# Altium schematic sheet Battery Fuel Gauge.SchDoc
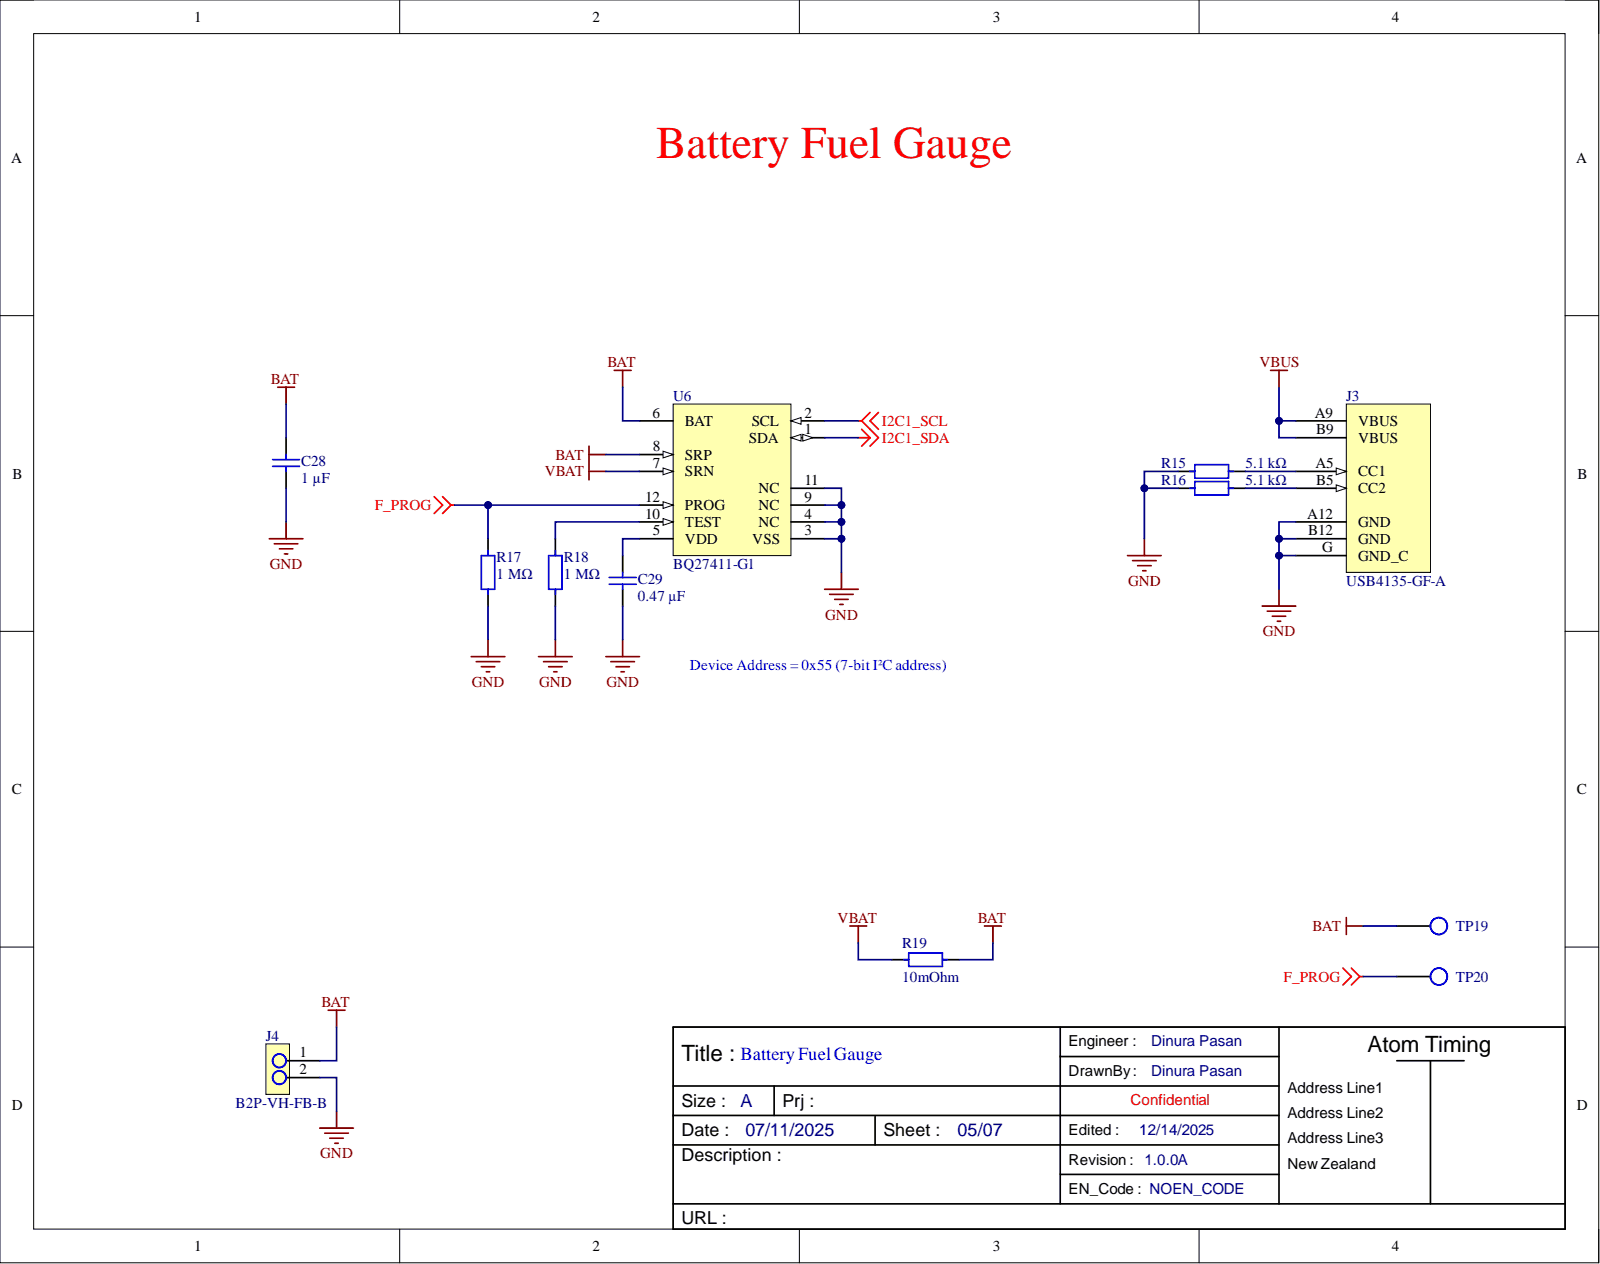
[diagram p0: Battery Fuel Gauge.SchDoc]

## Parts
C28  1 µF  [TDK_CGA0805N]
C29  0.47 µF  [TDK_CGA0805N]
J3  USB4135-GF-A  [GCT_USB4135]
J4  B2P-VH-FB-B  [B2P-VH-FB-B]
R15  5.1 kΩ  [YAGEO_RT0402N]
R16  5.1 kΩ  [YAGEO_RT0402N]
R17  1 MΩ  [YAGEO_RT0603N]
R18  1 MΩ  [YAGEO_RT0603N]
R19  10mOhm  [RL0805FR-070R01L]
TP19  TestPoint  [TESTPOINT]  (value not drawn)
TP20  TestPoint  [TESTPOINT]  (value not drawn)
U6  BQ27411-G1  [TI-DRZ0012A]

## Nets
BAT: C28.2 J4.1 R19.2 TP19.1 U6.6(BAT) U6.8(SRP)
F_PROG: R17.2 TP20.1 U6.12(PROG)
GND: C28.1 C29.1 J3.A12(GND) J3.B12(GND) J3.G(GND_C) J4.2 R15.1 R16.1 R17.1 R18.1 U6.3(VSS) U6.4(NC) U6.9(NC) U6.11(NC)
I2C1_SCL: U6.2(SCL)
I2C1_SDA: U6.1(SDA)
VBAT: R19.1 U6.7(SRN)
VBUS: J3.A9(VBUS) J3.B9(VBUS)
NetC29_2: C29.2 U6.5(VDD)
NetJ3_A5: J3.A5(CC1) R15.2
NetJ3_B5: J3.B5(CC2) R16.2
NetR18_2: R18.2 U6.10(TEST)

## Library data of the parts
C28 = CGA4J3X7R1H105K125AB: 1 µF ±10% 50V X7R -55°C ~ 125°C 0805 (2012 Metric); Manufacturer: TDK Corporation; Database Table Name: Sheet1$; Eco Plan: RoHS & Green; Initial Voltage: 0V; TEMP: 25; Value: 1u; Capacitance: 1 µF; Category: Ceramic Capacitor (MLCC); Component Kind: Standard; Datasheet: https://product.tdk.com/system/files/dam/doc/product/capacitor/ceramic/mlcc/catalog/mlcc_automotive_general_en.pdf; Library Name: MLCC.DbLib; Manufacturer Part Number: CGA4J3X7R1H105K125AB; Operating Temperature: -55°C ~ 125°C; Package / Case: 0805 (2012 Metric); Ratings: AEC-Q200; Series: CGA; Simulation: C_Capacitor_Default; Size / Dimension: 0.079" L x 0.049" W (2.00mm x 1.25mm); Supplier 1: Digi-Key; Supplier Part Number 1: 445-6961-2-ND; Temperature Coefficient: X7R; Thickness (Max): 0.059" (1.50mm); Tolerance: ±10%; Voltage - Rated: 50V
C29 = CGA4J3X7R1H474K125AE: 0.47 µF ±10% 50V X7R -55°C ~ 125°C 0805 (2012 Metric); Manufacturer: TDK Corporation; Database Table Name: Sheet1$; Eco Plan: RoHS & Green; Initial Voltage: 0V; TEMP: 25; Value: 0.47u; Capacitance: 0.47 µF; Category: Ceramic Capacitor (MLCC); Component Kind: Standard; Datasheet: https://product.tdk.com/system/files/dam/doc/product/capacitor/ceramic/mlcc/catalog/mlcc_automotive_general_en.pdf; Library Name: MLCC.DbLib; Manufacturer Part Number: CGA4J3X7R1H474K125AE; Operating Temperature: -55°C ~ 125°C; Package / Case: 0805 (2012 Metric); Ratings: AEC-Q200; Series: CGA; Simulation: C_Capacitor_Default; Size / Dimension: 0.079" L x 0.049" W (2.00mm x 1.25mm); Supplier 1: Digi-Key; Supplier Part Number 1: 445-8786-2-ND; Temperature Coefficient: X7R; Thickness (Max): 0.059" (1.50mm); Tolerance: ±10%; Voltage - Rated: 50V
J3 = USB4135-GF-A: USB Connector Type C Charging Receptacle (socket) No Surface Mount Horizontal; Manufacturer: GCT; Connector style: Type C; Current Rating: 3A; Database Table Name: Sheet1$; Material Flammability Rating: UL94 V-0; Mating Cycles: 20000; Mount position: Top Mount; Number of contacts: 6; Power Rating: 144W; Shielding: Shielded; Voltage Rating: 48V DC; Category: USB Connector; Component Kind: Standard; Contact Finish: Gold; Datasheet: https://gct.co/files/drawings/usb4135.pdf?v=17ab874a-bf69-4e78-8a8d-736f7353e97a; Gender: Receptacle (socket); Library Name: GCT_USB-C.DbLib; Manufacturer Part Number: USB4135-GF-A; Operating Temperature: -30°C ~ 85°C; Orientation: Horizontal; PCB mount type: Surface Mount; Series: USB4135; Shell/Shielding Material: Steel, Stainless; Supplier 1: Digi-Key; Supplier Part Number 1: 2073-USB4135-GF-ACT-ND; USB version: Charging; Waterproof: No
J4 = B2P-VH-FB-B: Connector Header Through Hole 2 position 0.156" (3.96mm); Manufacturer 1: JST; Manufacturer Part Number 1: B2P-VH-FB-B(LF)(SN); Category 1: Headers and Wire Housings; Connector Type: Male Pin; Current Rating: 10A; Description 1: Conn Shrouded Header (4 Sides) HDR 2 POS 3.96mm Solder ST Top Entry Thru-Hole Box; Number of Contacts: 2; Pitch: 3.9624mm; Voltage Rating: 250V; China RoHS: Compliant; Color: Natural; Component Kind: Standard; ComponentLink1Description: https://datasheet.octopart.com:443/B2P-VH-FB-B%28LF%29%28SN%29-JST-datasheet-41193408.pdf?src-supplier=IHS; ComponentLink1URL: https://datasheet.octopart.com:443/B2P-VH-FB-B%28LF%29%28SN%29-JST-datasheet-41193408.pdf?src-supplier=IHS; ComponentLink2Description: https://datasheet.octopart.com:443/B2P-VH-FB-B%28LF%29%28SN%29-JST-datasheet-151658475.pdf?src-supplier=LKR; ComponentLink2URL: https://datasheet.octopart.com:443/B2P-VH-FB-B%28LF%29%28SN%29-JST-datasheet-151658475.pdf?src-supplier=LKR; ComponentLink3Description: https://datasheet.octopart.com:443/B2P-VH-FB-B%28LF%29%28SN%29-JST-datasheet-12513069.pdf?src-supplier=Future+Electronics; ComponentLink3URL: https://datasheet.octopart.com:443/B2P-VH-FB-B%28LF%29%28SN%29-JST-datasheet-12513069.pdf?src-supplier=Future+Electronics; ComponentLink4Description: https://datasheet.octopart.com:443/B2P-VH-FB-B%28LF%29%28SN%29-JST-datasheet-10025879.pdf?src-supplier=Future+Electronics; ComponentLink4URL: https://datasheet.octopart.com:443/B2P-VH-FB-B%28LF%29%28SN%29-JST-datasheet-10025879.pdf?src-supplier=Future+Electronics; ComponentLink5Description: https://datasheet.octopart.com:443/B2P-VH-FB-B%28LF%29%28SN%29-JST-datasheet-5332680.pdf?src-supplier=Future+Electronics; ComponentLink5URL: https://datasheet.octopart.com:443/B2P-VH-FB-B%28LF%29%28SN%29-JST-datasheet-5332680.pdf?src-supplier=Future+Electronics; ComponentLink6Description: https://datasheet.octopart.com:443/B2P-VH-FB-B%28LF%29%28SN%29-JST-datasheet-16979.pdf?src-supplier=Future+Electronics; ComponentLink6URL: https://datasheet.octopart.com:443/B2P-VH-FB-B%28LF%29%28SN%29-JST-datasheet-16979.pdf?src-supplier=Future+Electronics; Contact Gender: Male; Contact Material: Brass; Contact Plating: Tin; Fastening Type: Ramp; Height: 11mm; Lead Free 1: Lead Free; Library Name: Components.IntLib; Lifecycle Status: Production; Max Operating Temperature 1: 85°C; Min Operating Temperature 1: -25°C; Mount 1: Through Hole; Number of Pins 1: 2; Number of Positions: 2; Number of Rows: 1; REACH SVHC: Yes; RoHS 1: Compliant; Supplier 1: Mouser; Supplier Part Number 1: 306-B2PVHFBBLFSN; Terminal Pitch: 3.96mm; Termination: Solder
R15 R16 = RT0402BRD075K1L: 5.1 kΩ ±0.1% 0.063W, 1/16W Thin Film 0402 (1005 Metric) 50V ±25ppm/°C -55°C ~ 155°C; Manufacturer: YAGEO; Database Table Name: Sheet1$; Eco Plan: RoHS & Green; Maximum Overload Voltage: 100V; Rated Voltage: √(PxR); TC: 25; TC1: 0; Value: 5.1kΩ; Category: SMD Thin Film Resistor; Component Kind: Standard; Composition: Thin Film; Datasheet: https://www.yageo.com/upload/media/product/products/datasheet/rchip/PYu-RT_1-to-0.01_RoHS_L_14.pdf; Height - Seated (Max): 0.014" (0.35mm); Library Name: SMD_Thin_Film_Resistors.DbLib; Manufacturer Part Number: RT0402BRD075K1L; Maximum Working Voltage: 50V; Operating Temperature: -55°C ~ 155°C; Package / Case: 0402 (1005 Metric); Power (Watts): 0.063W, 1/16W; Ratings: -; Resistance: 5.1 kΩ; Series: RT; Simulation: R_Resistor_Default; Size / Dimension: 0.039" L x 0.020" W (1.00mm x 0.50mm); Supplier 1: Digi-Key; Supplier Part Number 1: YAG1457TR-ND; TC2: 0; TEMP: 25; Temperature Coefficient: ±25ppm/°C; Tolerance: ±0.1%
R17 R18 = RT0603DRD071ML: 1 MΩ ±0.5% 0.1W, 1/10W Thin Film 0603 (1608 Metric) 75V ±25ppm/°C -55°C ~ 155°C; Manufacturer: YAGEO; Database Table Name: Sheet1$; Eco Plan: RoHS & Green; Maximum Overload Voltage: 150V; Rated Voltage: √(PxR); TC: 25; TC1: 0; Value: 1MΩ; Category: SMD Thin Film Resistor; Component Kind: Standard; Composition: Thin Film; Datasheet: https://www.yageo.com/upload/media/product/products/datasheet/rchip/PYu-RT_1-to-0.01_RoHS_L_14.pdf; Height - Seated (Max): 0.022" (0.55mm); Library Name: SMD_Thin_Film_Resistors.DbLib; Manufacturer Part Number: RT0603DRD071ML; Maximum Working Voltage: 75V; Operating Temperature: -55°C ~ 155°C; Package / Case: 0603 (1608 Metric); Power (Watts): 0.1W, 1/10W; Ratings: -; Resistance: 1 MΩ; Series: RT; Simulation: R_Resistor_Default; Size / Dimension: 0.063" L x 0.031" W (1.60mm x 0.80mm); Supplier 1: Digi-Key; Supplier Part Number 1: 13-RT0603DRD071MLTR-ND; TC2: 0; TEMP: 25; Temperature Coefficient: ±25ppm/°C; Tolerance: ±0.5%
R19 = 10mOhm: 10 mOhms ±1% 0.125W, 1/8W Chip Resistor 0805 (2012 Metric) Current Sense Thick Film; Manufacturer 1: Yageo Group; Case/Package 1: 0805; Category 1: Chip SMD Resistors; Description 1: Res Thick Film 0805 0.01 Ohm 1% 1/8W ±1500ppm/°C Molded SMD SMD Paper T/R; Max Power Dissipation: 125mW; Mount 1: Surface Mount; Packaging 1: Tape & Reel; Resistance: 10mΩ; Temperature Coefficient: 1500ppm/°C; Working Voltage: 35.4mV; Case Code (Imperial): 0805; Case Code (Metric): 2012; China RoHS: Non-Compliant; Component Kind: Standard; ComponentLink1Description: https://datasheet.octopart.com:443/RL0805FR-070R01L-Yageo-datasheet-182189623.pdf?src-supplier=IHS; ComponentLink1URL: https://datasheet.octopart.com:443/RL0805FR-070R01L-Yageo-datasheet-182189623.pdf?src-supplier=IHS; ComponentLink2Description: https://datasheet.octopart.com:443/RL0805FR-070R01L-Yageo-datasheet-137700928.pdf?src-supplier=Future+Electronics; ComponentLink2URL: https://datasheet.octopart.com:443/RL0805FR-070R01L-Yageo-datasheet-137700928.pdf?src-supplier=Future+Electronics; ComponentLink3Description: https://datasheet.octopart.com/RL0805FR-070R01L-Yageo-datasheet-10916029.pdf; ComponentLink3URL: https://datasheet.octopart.com/RL0805FR-070R01L-Yageo-datasheet-10916029.pdf; ComponentLink4Description: http://sigma.octopart.com:80/rohs_statement/Yageo-company-2.pdf?src-supplier=Compliance+Statements; ComponentLink4URL: http://sigma.octopart.com:80/rohs_statement/Yageo-company-2.pdf?src-supplier=Compliance+Statements; ComponentLink5Description: http://sigma.octopart.com:80/conflict_mineral_statement/Yageo-company-3.pdf?src-supplier=Compliance+Statements; ComponentLink5URL: http://sigma.octopart.com:80/conflict_mineral_statement/Yageo-company-3.pdf?src-supplier=Compliance+Statements; Composition: Thick Film; Contact Plating: Tin; Depth: 1.25mm; Features: Moisture Resistant; Height: 500µm; Lead Free 1: Lead Free; Length: 2mm; Library Name: Components.IntLib; Lifecycle Status: Production; Manufacturer Part Number 1: RL0805FR-070R01L; Max Operating Temperature 1: 155°C; Military Standard: Not; Min Operating Temperature 1: -55°C; Number of Terminals: 2; Number of Terminations: 2; Power Dissipation 1: 125mW; Power Rating: 125mW; Radiation Hardening: No; REACH SVHC: Yes; RoHS 1: Compliant; Schedule B: 8533210045, 8533210045|8533210045, 8533210045|8533210045|8533210045, 8533210045|8533210045|8533210045|8533210045; Supplier 1: DigiKey; Supplier 2: Mouser; Supplier Part Number 1: 311-0.01SCT-ND; Supplier Part Number 2: 603-RL0805FR-070R01L; Termination: SMD/SMT; Tolerance: 1%; Weight 1: 5.499807mg; Width: 1.25mm
TP19 TP20 = TestPoint: 1mm Test Point; Component Kind: Standard; Library Name: Common_library.IntLib
U6 = BQ27411-G1: Single-Cell Li-Ion Battery Fuel Gauge for Battery Pack Integration; Manufacturer 1: Texas Instruments; Manufacturer Lifecycle Status: ACTIVE; Manufacturer Part Number 1: BQ27411DRZT-G1A; Category 1: Battery Management; Description 1: Pack-side Impedance Track™ fuel gauge | battery gas gauge 12-SON -40 to 85; Interface 1: I2C; Max Supply Voltage 1: 4.5V; Min Supply Voltage 1: 2.45V; Mount 1: Surface Mount; Number of Cells: 1; Number of Pins 1: 12; Operating Supply Current: 93µA; Output Current 1: 500µA; Output Voltage 1: 1.8V; Component Kind: Standard; ComponentLink1Description: https://sigma.octopart.com:443/164511800/technical_drawing/Texas-Instruments-BQ27411DRZT-G1A.pdf?src-supplier=Texas+Instruments; ComponentLink1URL: https://sigma.octopart.com:443/164511800/technical_drawing/Texas-Instruments-BQ27411DRZT-G1A.pdf?src-supplier=Texas+Instruments; ComponentLink2Description: https://octopart.com:443/opatz8j6/c1?t=tAaHEBVBM_9RKvjiZd4SMl5sbqL3v42YjabZCVUahrU8X3mdUb-ZA6-YauRJua-SBCZvM5HZ9Pgw6oDj0CSTOOT81v6DKAk8vwvn-CIDGh0GHw2o98roWGpx6_5eIYk6v4zjTJZf-EBgsU_FsLQTAYUUILlthmnQYSJcpSs7Z-sSRsPB1pBYEb8RGsNbuZ8L4t1gp6ZJEYpzQLx5zHpNB4S4AqwPi85S15pm27MNPg8USkmgRZfkzu5_5M9km_Eulyk9tW9kf5AC3maqSHIdKi9VIq0XiRctN9qpnMuIVAKBH474UXkDyJ3bMA8BZrOPQSP8phbaxiDM2Jz0ON_OPHCzh81NgYWvVhJ4VgattihaX11wetZLj2U7Y4TT6P0KBv-bHXAOlQ&src-supplier=Texas+Instruments; ComponentLink2URL: https://octopart.com:443/opatz8j6/c1?t=tAaHEBVBM_9RKvjiZd4SMl5sbqL3v42YjabZCVUahrU8X3mdUb-ZA6-YauRJua-SBCZvM5HZ9Pgw6oDj0CSTOOT81v6DKAk8vwvn-CIDGh0GHw2o98roWGpx6_5eIYk6v4zjTJZf-EBgsU_FsLQTAYUUILlthmnQYSJcpSs7Z-sSRsPB1pBYEb8RGsNbuZ8L4t1gp6ZJEYpzQLx5zHpNB4S4AqwPi85S15pm27MNPg8USkmgRZfkzu5_5M9km_Eulyk9tW9kf5AC3maqSHIdKi9VIq0XiRctN9qpnMuIVAKBH474UXkDyJ3bMA8BZrOPQSP8phbaxiDM2Jz0ON_OPHCzh81NgYWvVhJ4VgattihaX11wetZLj2U7Y4TT6P0KBv-bHXAOlQ&src-supplier=Texas+Instruments; ComponentLink3Description: https://datasheet.octopart.com:443/BQ27411DRZT-G1A-Texas-Instruments-datasheet-32736855.pdf?src-supplier=Texas+Instruments; ComponentLink3URL: https://datasheet.octopart.com:443/BQ27411DRZT-G1A-Texas-Instruments-datasheet-32736855.pdf?src-supplier=Texas+Instruments; ComponentLink4Description: https://datasheet.octopart.com:443/BQ27411DRZT-G1A-Texas-Instruments-datasheet-22056620.pdf?src-supplier=Texas+Instruments; ComponentLink4URL: https://datasheet.octopart.com:443/BQ27411DRZT-G1A-Texas-Instruments-datasheet-22056620.pdf?src-supplier=Texas+Instruments; ComponentLink5Description: https://sigma.octopart.com:443/49250810/technical_drawing/Texas-Instruments-BQ27411DRZT-G1A.pdf?src-supplier=Texas+Instruments; ComponentLink5URL: https://sigma.octopart.com:443/49250810/technical_drawing/Texas-Instruments-BQ27411DRZT-G1A.pdf?src-supplier=Texas+Instruments; ComponentLink6Description: https://datasheet.octopart.com:443/BQ27411DRZT-G1A-Texas-Instruments-datasheet-34158188.pdf?src-supplier=Newark; ComponentLink6URL: https://datasheet.octopart.com:443/BQ27411DRZT-G1A-Texas-Instruments-datasheet-34158188.pdf?src-supplier=Newark; ComponentLink7Description: https://datasheet.octopart.com:443/BQ27411DRZT-G1A-Texas-Instruments-datasheet-65712763.pdf?src-supplier=Newark; ComponentLink7URL: https://datasheet.octopart.com:443/BQ27411DRZT-G1A-Texas-Instruments-datasheet-65712763.pdf?src-supplier=Newark; ComponentLink8Description: https://datasheet.octopart.com:443/BQ27411DRZT-G1A-Texas-Instruments-datasheet-23714530.pdf?src-supplier=Newark; ComponentLink8URL: https://datasheet.octopart.com:443/BQ27411DRZT-G1A-Texas-Instruments-datasheet-23714530.pdf?src-supplier=Newark; ComponentLink9Description: https://datasheet.octopart.com:443/BQ27411DRZT-G1A-Texas-Instruments-datasheet-62115679.pdf?src-supplier=element14+APAC; ComponentLink9URL: https://datasheet.octopart.com:443/BQ27411DRZT-G1A-Texas-Instruments-datasheet-62115679.pdf?src-supplier=element14+APAC; ComponentLink10Description: https://datasheet.octopart.com:443/BQ27411DRZT-G1A-Texas-Instruments-datasheet-166221677.pdf?src-supplier=LCSC; ComponentLink10URL: https://datasheet.octopart.com:443/BQ27411DRZT-G1A-Texas-Instruments-datasheet-166221677.pdf?src-supplier=LCSC; ComponentLink11Description: https://datasheet.octopart.com:443/BQ27411DRZT-G1A-Texas-Instruments-datasheet-164412999.pdf?src-supplier=SHENGYU+ELECTRONICS; ComponentLink11URL: https://datasheet.octopart.com:443/BQ27411DRZT-G1A-Texas-Instruments-datasheet-164412999.pdf?src-supplier=SHENGYU+ELECTRONICS; ComponentLink12Description: https://datasheet.octopart.com:443/BQ27411DRZT-G1A-Texas-Instruments-datasheet-142407043.pdf?src-supplier=Arrow.cn; ComponentLink12URL: https://datasheet.octopart.com:443/BQ27411DRZT-G1A-Texas-Instruments-datasheet-142407043.pdf?src-supplier=Arrow.cn; ComponentLink13Description: http://sigma.octopart.com:80/conflict_mineral_statement/Texas-Instruments-company-63.pdf?src-supplier=Compliance+Statements; ComponentLink13URL: http://sigma.octopart.com:80/conflict_mineral_statement/Texas-Instruments-company-63.pdf?src-supplier=Compliance+Statements; ComponentLink14Description: http://sigma.octopart.com:80/reach_statement/Texas-Instruments-company-64.pdf?src-supplier=Compliance+Statements; ComponentLink14URL: http://sigma.octopart.com:80/reach_statement/Texas-Instruments-company-64.pdf?src-supplier=Compliance+Statements; Contact Plating: Gold; Height: 0m; Lead Free 1: Lead Free; Length: 4mm; Library Name: ICs.IntLib; Lifecycle Status: Production; Manufacturer Part Number 2: BQ27411DRZR-G1A; Manufacturer Part Number 3: BQ27411DRZR-G1C; Manufacturer Part Number 4: BQ27411DRZT-G1C; Manufacturer Part Number 5: BQ27411DRZT-G1CG4; Max Operating Temperature 1: 85°C; Min Operating Temperature 1: -40°C; REACH SVHC: No; RoHS 1: Compliant; Schedule B: 8542390000; Supplier 1: DigiKey; Supplier 2: DigiKey; Supplier 3: DigiKey; Supplier 4: Mouser; Supplier 5: LCSC; Supplier 6: Arrow Electronics; Supplier 7: DigiKey; Supplier 8: DigiKey; Supplier 9: DigiKey; Supplier 10: DigiKey; Supplier 11: DigiKey; Supplier 12: Mouser; Supplier 13: Mouser; Supplier Part Number 1: 296-37269-1-ND; Supplier Part Number 2: 296-37269-6-ND; Supplier Part Number 3: 296-37269-2-ND; Supplier Part Number 4: 595-BQ27411DRZT-G1A; Supplier Part Number 5: C2878137; Supplier Part Number 6: BQ27411DRZR-G1A; Supplier Part Number 7: 296-39935-2-ND; Supplier Part Number 8: 296-39936-2-ND; Supplier Part Number 9: 296-37270-1-ND; Supplier Part Number 10: 296-37270-6-ND; Supplier Part Number 11: 296-37270-2-ND; Supplier Part Number 12: 595-BQ27411DRZT-G1C; Supplier Part Number 13: 595-BQ27411DRZT-G1C4; Thickness: 850µm; Width: 2.5mm
